# Altium schematic sheet I2C_Shield.SchDoc(I2C_Shield)
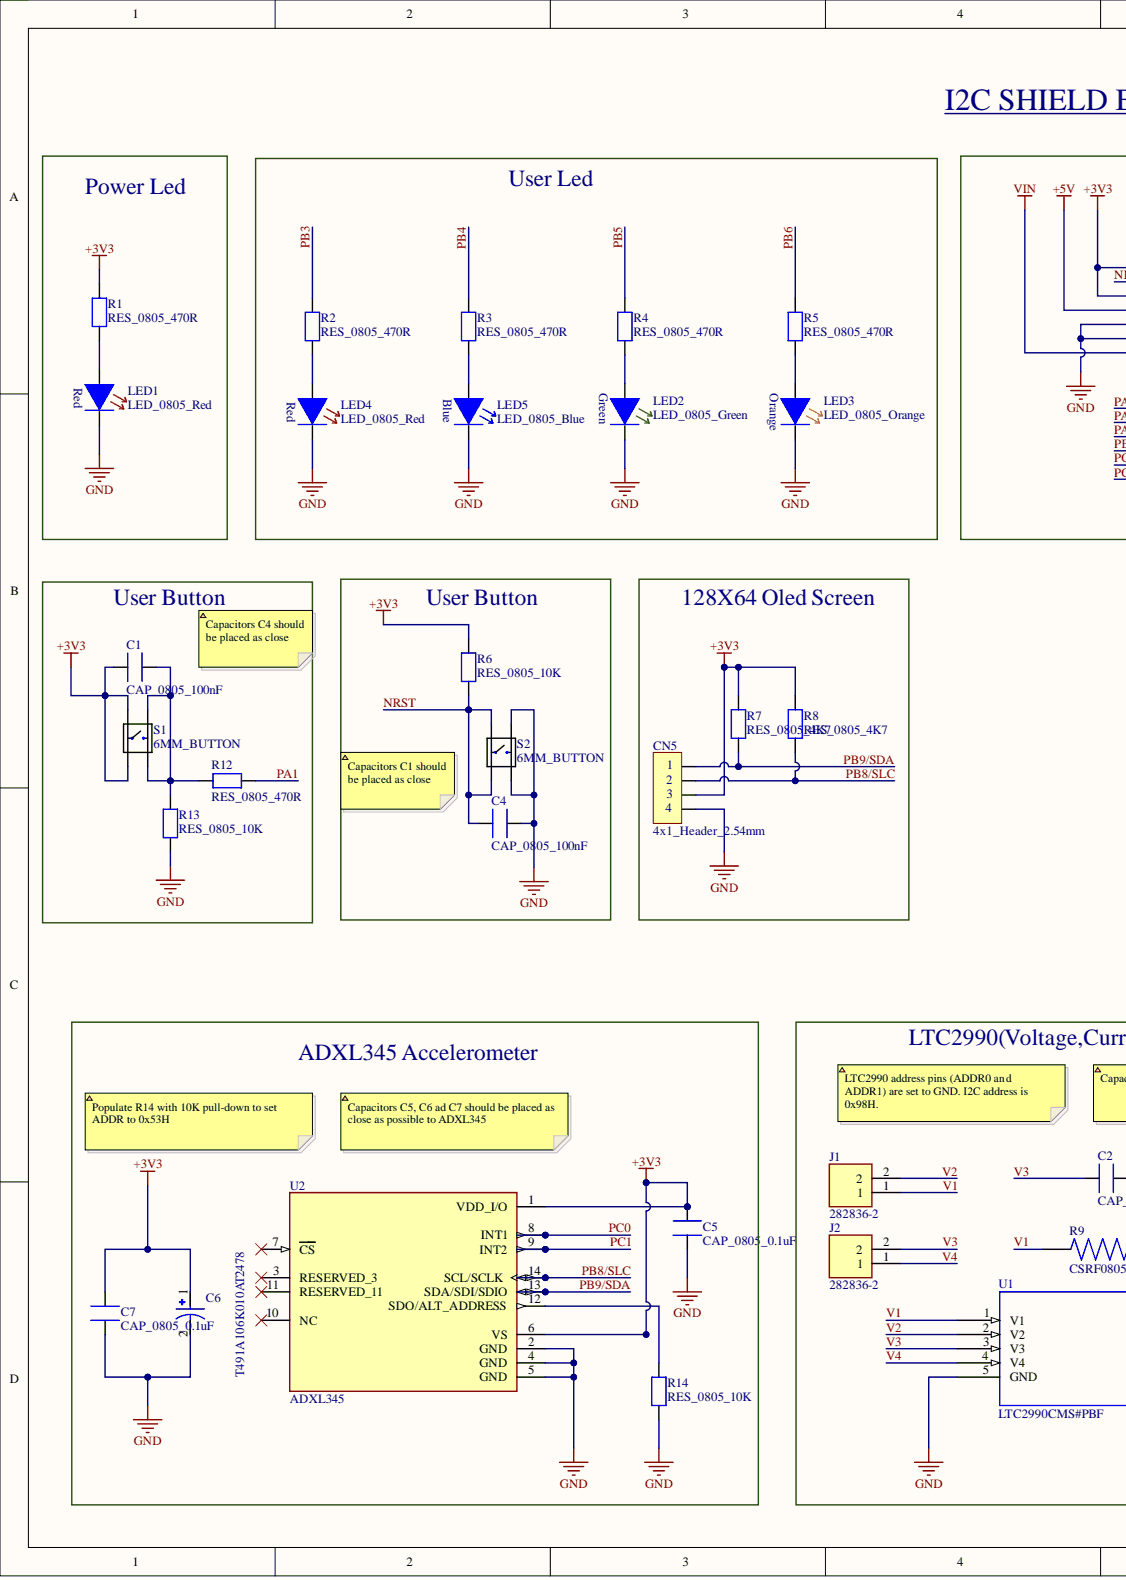
[diagram p0: I2C_Shield.SchDoc, left]
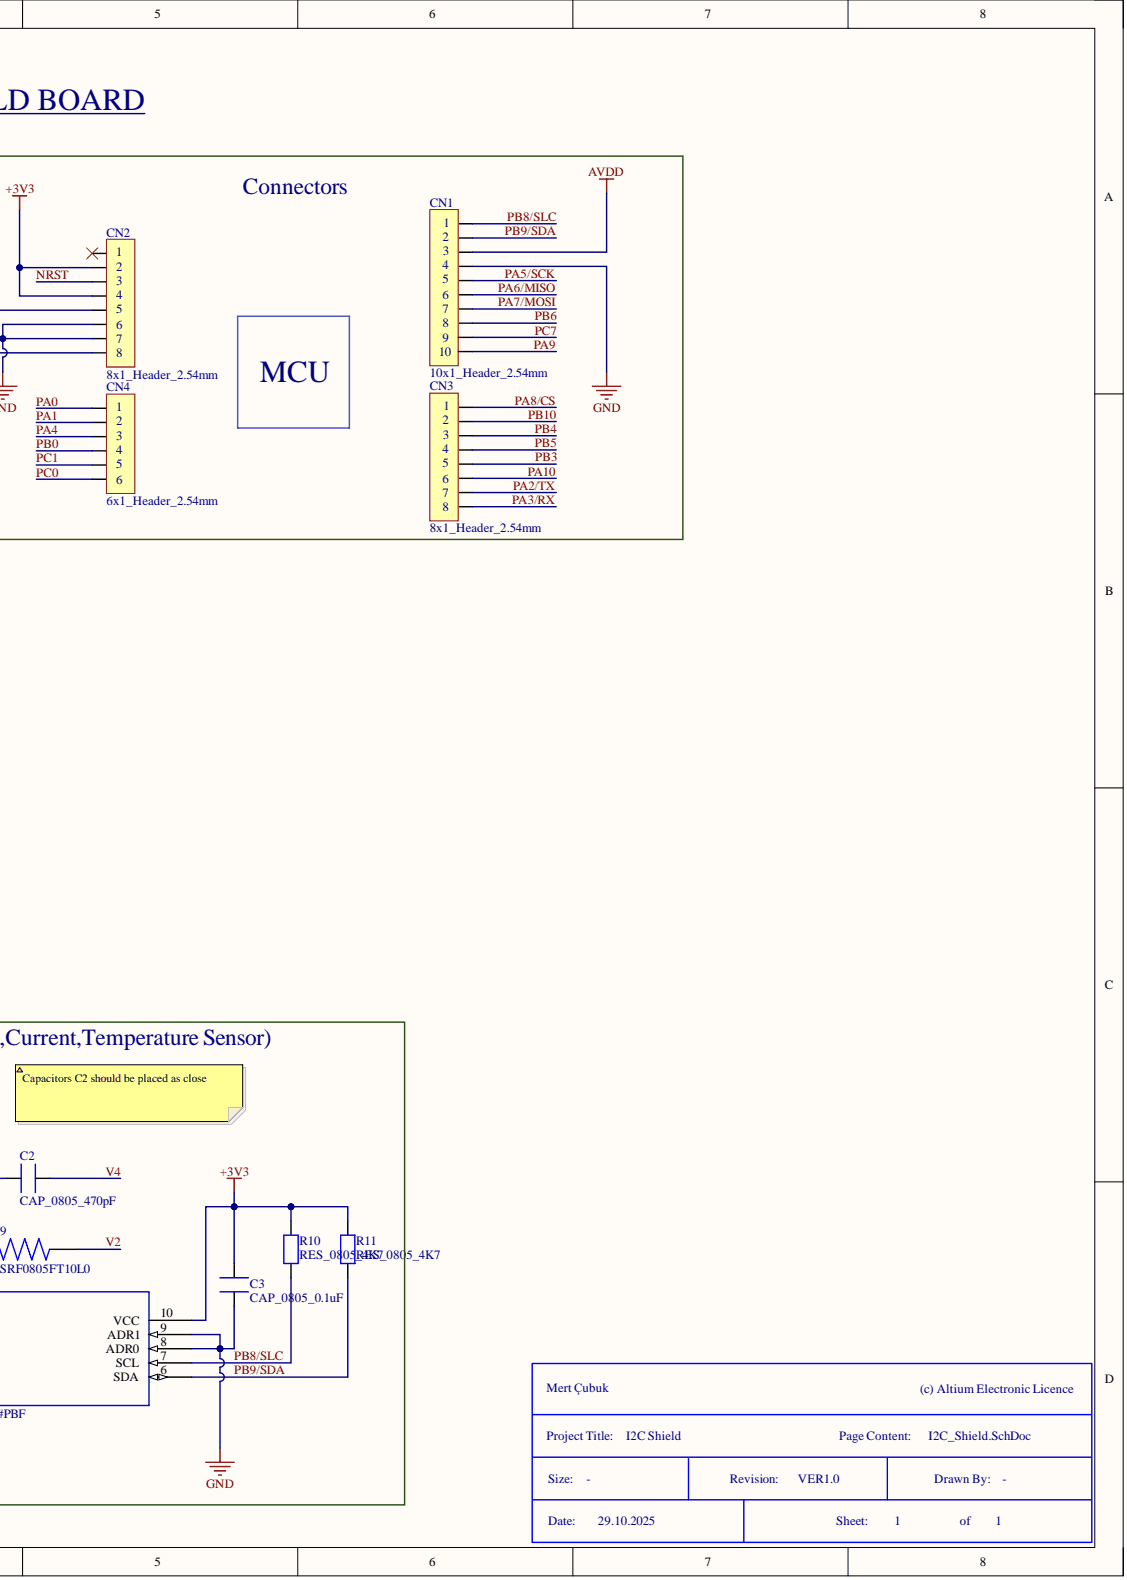
[diagram p1: I2C_Shield.SchDoc, right]

## Parts
C1  CAP_0805_100nF  [CAP_0805]
C2  CAP_0805_470pF  [CAP_0805]
C3  CAP_0805_0.1uF  [CAP_0805]
C4  CAP_0805_100nF  [CAP_0805]
C5  CAP_0805_0.1uF  [CAP_0805]
C6  T491A106K010AT2478  [CAPMP3216X180N]
C7  CAP_0805_0.1uF  [CAP_0805]
CN1  10x1_Header_2.54mm  [10X1_2.54MM_HEADER]
CN2  8x1_Header_2.54mm  [8X1_2.54MM_HEADER]
CN3  8x1_Header_2.54mm  [8X1_2.54MM_HEADER]
CN4  6x1_Header_2.54mm  [6X1_2.54MM_HEADER]
CN5  4x1_Header_2.54mm  [4X1_2.54MM_HEADER]
J1  282836-2  [TE_282836-2]
J2  282836-2  [TE_282836-2]
LED1  LED_0805_Red  [LED_0805]
LED2  LED_0805_Green  [LED_0805]
LED3  LED_0805_Orange  [LED_0805]
LED4  LED_0805_Red  [LED_0805]
LED5  LED_0805_Blue  [LED_0805]
R1  RES_0805_470R  [RES_0805]
R2  RES_0805_470R  [RES_0805]
R3  RES_0805_470R  [RES_0805]
R4  RES_0805_470R  [RES_0805]
R5  RES_0805_470R  [RES_0805]
R6  RES_0805_10K  [RES_0805]
R7  RES_0805_4K7  [RES_0805]
R8  RES_0805_4K7  [RES_0805]
R9  CSRF0805FT10L0  [RESC2113X85N]
R10  RES_0805_4K7  [RES_0805]
R11  RES_0805_4K7  [RES_0805]
R12  RES_0805_470R  [RES_0805]
R13  RES_0805_10K  [RES_0805]
R14  RES_0805_10K  [RES_0805]
S1  6MM_BUTTON  [BUTTON_6MM]
S2  6MM_BUTTON  [BUTTON_6MM]
U1  LTC2990CMS#PBF  [MSOP-10_MS]
U2  ADXL345  [PQFN80P500X300X100-14N]

## Nets
+3V3: C1.1 C3.2 C5.2 C6.1 C7.2 CN2.02 CN2.04 CN5.03 R1.2 R6.2 R7.2 R8.2 R10.2 R11.2 S1.2 S1.4 U1.10(VCC) U2.1(VDD_I/O) U2.6(VS)
+5V: CN2.05
AVDD: CN1.03
GND: C3.1 C4.2 C5.1 C6.2 C7.1 CN1.04 CN2.06 CN2.07 CN5.04 LED1.C LED2.C LED3.C LED4.C LED5.C R13.1 R14.1 S2.1 S2.3 U1.5(GND) U1.8(ADR0) U1.9(ADR1) U2.2(GND) U2.4(GND) U2.5(GND)
VIN: CN2.08
NRST: C4.1 CN2.03 R6.1 S2.2 S2.4
PA0: CN4.01
PA1: CN4.02 R12.2
PA2/TX: CN3.07
PA3/RX: CN3.08
PA4: CN4.03
PA5/SCK: CN1.05
PA6/MISO: CN1.06
PA7/MOSI: CN1.07
PA8/CS: CN3.01
PA9: CN1.10
PA10: CN3.06
PB0: CN4.04
PB3: CN3.05 R2.2
PB4: CN3.03 R3.2
PB5: CN3.04 R4.2
PB6: CN1.08 R5.2
PB8/SLC: CN1.01 CN5.02 R8.1 R10.1 U1.7(SCL) U2.14(SCL/SCLK)
PB9/SDA: CN1.02 CN5.01 R7.1 R11.1 U1.6(SDA) U2.13(SDA/SDI/SDIO)
PB10: CN3.02
PC0: CN4.06 U2.8(INT1)
PC1: CN4.05 U2.9(INT2)
PC7: CN1.09
V1: J1.1 R9.1 U1.1(V1)
V2: J1.2 R9.2 U1.2(V2)
V3: C2.1 J2.2 U1.3(V3)
V4: C2.2 J2.1 U1.4(V4)
NetC1_2: C1.2 R12.1 R13.2 S1.1 S1.3
NetLED1_A: LED1.A R1.1
NetLED2_A: LED2.A R4.1
NetLED3_A: LED3.A R5.1
NetLED4_A: LED4.A R2.1
NetLED5_A: LED5.A R3.1
NetR14_2: R14.2 U2.12(SDO/ALT_ADDRESS)

Not connected: CN2 01; U2 3(RESERVED_3) 7(CS) 10(NC) 11(RESERVED_11)

## Library data of the parts
C1 C4 = CAP_0805_100nF: MLCC (2012) 0805 100nF 16VDC ±10% X7R; Manufacturer: CC0805KRX7R7BB104; Tolerance: +10%; Voltage Rating: 16V; Component Kind: Standard; Dielectric: X7R; Library Name: I2C_Shield.SchLib; Package Size: 0805
C2 = CAP_0805_470pF: MLCC (2012) 0805 470pF16VDC ±10% X7R; Manufacturer: CC0805KRX7R7BB104; Tolerance: +10%; Voltage Rating: 16V; Component Kind: Standard; Dielectric: X7R; Library Name: I2C_Shield.SchLib; Package Size: 0805
C3 C5 C7 = CAP_0805_0.1uF: MLCC (2012) 0805 0.1uF16VDC ±10% X7R; Manufacturer: CC0805KRX7R7BB104; Tolerance: +10%; Voltage Rating: 16V; Component Kind: Standard; Dielectric: X7R; Library Name: I2C_Shield.SchLib; Package Size: 0805
C6 = T491A106K010AT2478: 10 ÂµF Molded Tantalum Capacitors 10 V 1206 (3216 Metric) 3.8Ohm; MF: KEMET; Availability: In Stock; Check_prices: https://www.snapeda.com/parts/T491A106K010AT2478/KEMET/view-part/?ref=eda; Component Kind: Standard; Library Name: T491A106K010AT2478.IntLib; MP: T491A106K010AT2478; Package: SMD-2 KEMET; Price: None; Purchase-URL: https://pricing.snapeda.com/search/part/T491A106K010AT2478/?ref=eda; SnapEDA_Link: https://www.snapeda.com/parts/T491A106K010AT2478/KEMET/view-part/?ref=snap
CN1 = 10x1_Header_2.54mm: Arduino Stackable Header - Arduino Sheild Connector; Component Kind: Standard; Library Name: I2C_Shield.SchLib
CN2 CN3 = 8x1_Header_2.54mm: Arduino Stackable Header - Arduino Sheild Connector; Component Kind: Standard; Library Name: I2C_Shield.SchLib
CN4 = 6x1_Header_2.54mm: Arduino Stackable Header - Arduino Sheild Connector; Component Kind: Standard; Library Name: I2C_Shield.SchLib
CN5 = 4x1_Header_2.54mm: Oled Screen Header; Component Kind: Standard; Library Name: I2C_Shield.SchLib
J1 J2 = 282836-2: Connector, Terminal Block; Wire Receptacle; 2 Pos.; 16-30 AWG; 0.197 in. CL; Sid; MF: TE Connectivity; Availability: In Stock; Check_prices: https://www.snapeda.com/parts/282836-2/TE+Connectivity+AMP+Connectors/view-part/?ref=eda; Component Kind: Standard; Library Name: 282836-2.IntLib; MP: 282836-2; Package: None; Price: None; Purchase-URL: https://pricing.snapeda.com/search/part/282836-2/?ref=eda; SnapEDA_Link: https://www.snapeda.com/parts/282836-2/TE+Connectivity+AMP+Connectors/view-part/?ref=snap
LED1 LED4 = LED_0805_Red: LED 0805 RED 2.8-3.0V SMD; Manufacturer: GTG2012UBGC; Current: 30mA; Voltage Rating: 2-8-3.4V; Component Kind: Standard; Library Name: I2C_Shield.SchLib; Package Size: 0805
LED2 = LED_0805_Green: LED 0805 GREEN 2.8-3.0V SMD; Manufacturer: GTG2012UBGC; Current: 30mA; Voltage Rating: 2-8-3.4V; Component Kind: Standard; Library Name: I2C_Shield.SchLib; Package Size: 0805
LED3 = LED_0805_Orange: LED 0805 ORANGE 2.8-3.0V SMD; Manufacturer: GTG2012UBGC; Current: 30mA; Voltage Rating: 2-8-3.4V; Component Kind: Standard; Library Name: I2C_Shield.SchLib; Package Size: 0805
LED5 = LED_0805_Blue: LED 0805 BLUE 2.8-3.0V SMD; Manufacturer: GTG2012UBGC; Current: 30mA; Voltage Rating: 2-8-3.4V; Component Kind: Standard; Library Name: I2C_Shield.SchLib; Package Size: 0805
R1-R5 R12 = RES_0805_470R: RES.(2012) 0805 470 Ohms 1% 1/8W-S 100PPM; Manufacturer: CRT05F7E4700; Power: 1/8W; Tolerance: +1%; Voltage Rating: 150; Component Kind: Standard; Library Name: I2C_Shield.SchLib; Package Size: 0805
R6 R13 R14 = RES_0805_10K: RES.(2012) 0805 10K Ohms 5% 1/8W 100PPM SMT; Manufacturer: RC0805JR-0710KL; Tolerance: +5%; Voltage Rating: 150V; Component Kind: Standard; Library Name: I2C_Shield.SchLib; Package Size: 0805; Power: 1/8W
R7 R8 R10 R11 = RES_0805_4K7: RES.(2012) 0805 4K7 Ohms 5% 1/8W 100PPM SMT; Manufacturer: RC0805JR-0710KL; Tolerance: +5%; Voltage Rating: 150V; Component Kind: Standard; Library Name: I2C_Shield.SchLib; Package Size: 0805; Power: 1/8W
R9 = CSRF0805FT10L0: 10 mOhms Â±1% 0.5W, 1/2W Chip Resistor 0805 (2012 Metric) Automotive AEC-Q200, Current Sense, Moisture Resistant Metal Foil; MF: Stackpole Electronics; Check_prices: https://www.snapeda.com/parts/CSRF0805FT10L0/Stackpole+Electronics/view-part/?ref=eda; Component Kind: Standard; Library Name: CSRF0805FT10L0.IntLib; MP: CSRF0805FT10L0; Package: 0805 Stackpole Electronics; SnapEDA_Link: https://www.snapeda.com/parts/CSRF0805FT10L0/Stackpole+Electronics/view-part/?ref=snap
S1 S2 = 6mm_Button: SWITCH TACT 6x6MM 11MM 250gf SMD; Manufacturer: DS1042-03-116-KKR-250-10; Current: 50mA; Voltage Rating: 12V; Component Kind: Standard; Library Name: I2C_Shield.SchLib; Package Size: 6mmx6mm
U1 = LTC2990CMS#PBF: IC, SUPERVISORY, 1.1mA, 5.5V, MSOP10; No; IC, SUPERVISORY, 1.1mA, 5.5V, MSOP10; No. of Supervisors / Monitors:4; Supply Voltage Range:2.9V to 5.5V; Digital IC Case Style:MSOP; No. of Pins:10; Supply Current:1.1mA; Delay Time:46ms; Operating Temperature Ra; MF: Analog Devices; Check_prices: https://www.snapeda.com/parts/LTC2990CMS%23PBF/Analog+Devices/view-part/?ref=eda; Component Kind: Standard; Library Name: LTC2990CMS_PBF.IntLib; MP: LTC2990CMS#PBF; Package: MSOP-10 Linear Technology; SnapEDA_Link: https://www.snapeda.com/parts/LTC2990CMS%23PBF/Analog+Devices/view-part/?ref=snap
U2 = ADXL345: Accelerometer X, Y, Z Axis ±2g, 4g, 8g, 16g 0.05Hz ~ 1.6kHz 14-LGA (3x5); MF: Analog Devices, Inc.; Check_prices: https://www.snapeda.com/parts/ADXL345/Analog+Devices/view-part/?ref=eda; Component Kind: Standard; Library Name: ADXL345.IntLib; MP: ADXL345; Package: LGA -14 Analog Devices; SnapEDA_Link: https://www.snapeda.com/parts/ADXL345/Analog+Devices/view-part/?ref=snap
